# Altium schematic sheet SRLatch.SchDoc(SRLatch)
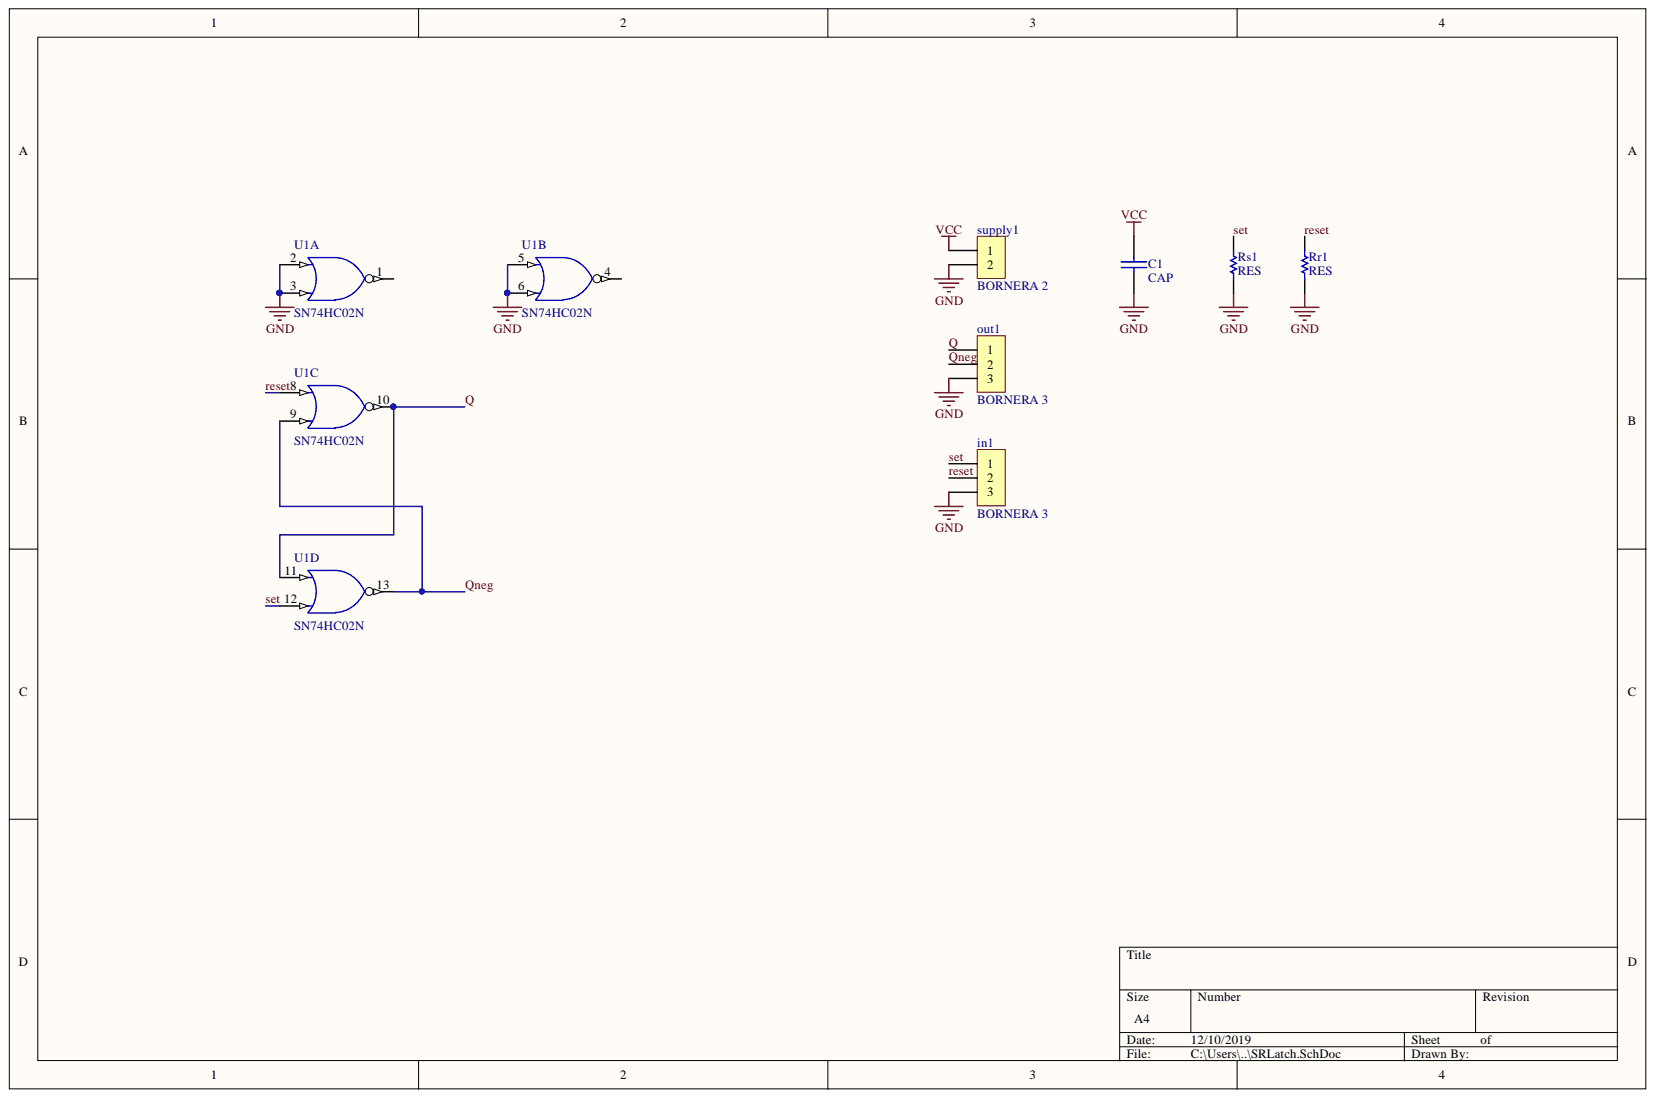
[diagram p0: SRLatch.SchDoc]

## Parts
C1  CAP  [CAP100]
in1  BORNERA 3  [BORNERA3]
out1  BORNERA 3  [BORNERA3]
Rr1  RES  [0805]
Rs1  RES  [0805]
supply1  BORNERA 2  [BORNERA2]
U1  SN74HC02N  [N014]  (parts A,B,C,D)

## Nets
GND: C1.2 in1.3 out1.3 Rr1.1 Rs1.1 supply1.2 U1.2 U1.3 U1.5 U1.6 U1.7
VCC: C1.1 supply1.1 U1.14
Q: out1.1 U1.10 U1.11
Qneg: out1.2 U1.9 U1.13
reset: in1.2 Rr1.2 U1.8
set: in1.1 Rs1.2 U1.12

Not connected: U1 1 4

## Library data of the parts
U1 = SN74HC02N: Quadruple 2-Input Positive-NOR Gate; Signal Integrity: SN74HC02N; Published: 8-Jun-2000; PCB3D: N014; PackageVersion: Oct-1995; PackageReference: N014; PackageDescription: DIP; 14 Leads; Row Spacing 7.62 mm; Pitch 2.54 mm; Library Name: TI Logic Gate 2.IntLib; LatestRevisionNote: Stylized 3D Model Added.; LatestRevisionDate: 04-Mar-2005; DatasheetVersion: May-1997; ComponentLink2URL: http://www-s.ti.com/sc/ds/sn74hc02.pdf; ComponentLink2Description: Datasheet; ComponentLink1URL: http://www.ti.com/; ComponentLink1Description: Manufacturer Link; Component Kind: Standard; Publisher: Altium Limited
C1 = CAP: Library Name: LIBEBAL.IntLib; Component Kind: Standard
in1 out1 = BORNERA 3: Library Name: LIBEBAL.IntLib; Component Kind: Standard
Rr1 Rs1 = RES: Library Name: LIBEBAL.IntLib; Component Kind: Standard
supply1 = BORNERA 2: Library Name: LIBEBAL.IntLib; Component Kind: Standard
